# Altium schematic sheet TFT_LCD.SchDoc
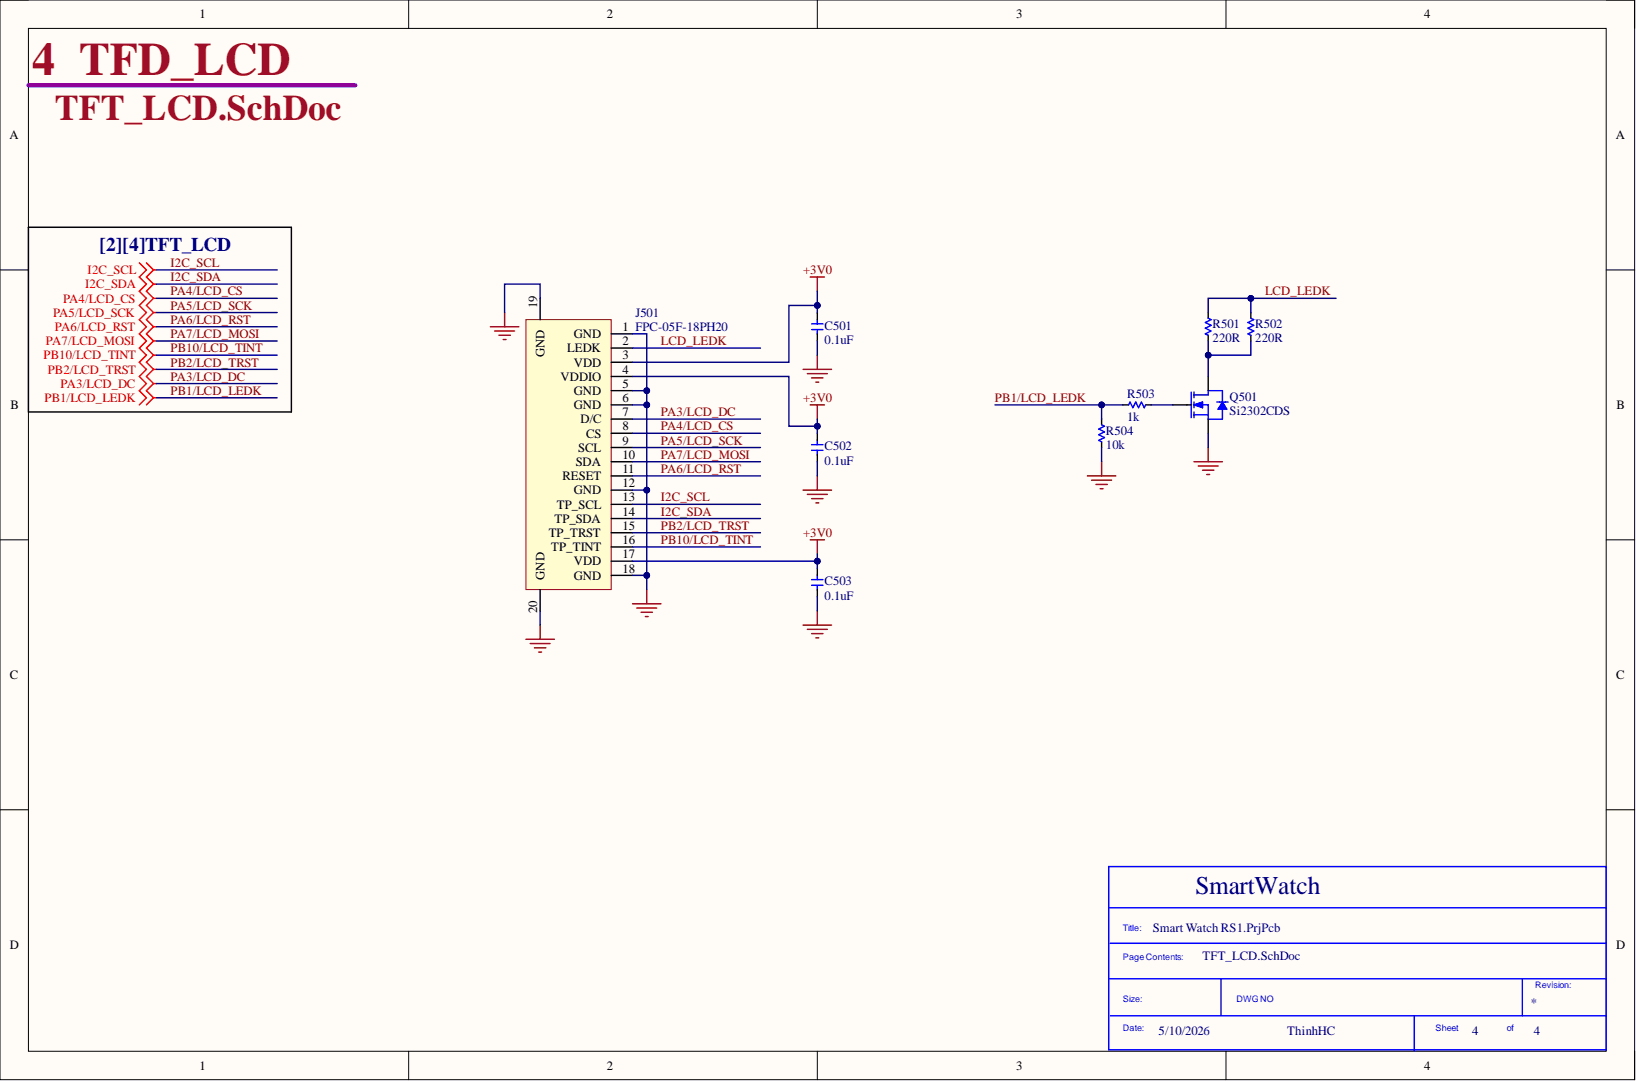
[diagram p0: TFT_LCD.SchDoc]

## Parts
C501  0.1uF  [C_0402]
C502  0.1uF  [C_0402]
C503  0.1uF  [C_0402]
J501  FPC-05F-18PH20  [FPC-SMD_18P-P0.50_FPC-05F-18PH20]
Q501  Si2302CDS  [SOT-23-3_L2.9-W1.3-P1.90-LS2.4-BR]
R501  220R  [R_0402]
R502  220R  [R_0402]
R503  1k  [R_0402]
R504  10k  [R_0402]

## Nets
+3V0: C501.2 C502.2 C503.2 J501.3(VDD) J501.4(VDDIO) J501.17(VDD)
GND: C501.1 C502.1 C503.1 J501.1(GND) J501.5(GND) J501.6(GND) J501.12(GND) J501.18(GND) J501.19(GND) J501.20(GND) Q501.2 R504.1
I2C_SCL: J501.13(TP_SCL)
I2C_SDA: J501.14(TP_SDA)
LCD_LEDK: J501.2(LEDK) R501.2 R502.2
PA3/LCD_DC: J501.7(D/C)
PA4/LCD_CS: J501.8(CS)
PA5/LCD_SCK: J501.9(SCL)
PA6/LCD_RST: J501.11(RESET)
PA7/LCD_MOSI: J501.10(SDA)
PB1/LCD_LEDK: R503.1 R504.2
PB2/LCD_TRST: J501.15(TP_TRST)
PB10/LCD_TINT: J501.16(TP_TINT)
NetQ501_1: Q501.1 R503.2
NetQ501_3: Q501.3 R501.1 R502.1

## Library data of the parts
C501-C503 = CL05B104KO5NNNC: 100nF 0402 16V X7R ±10% Multilayer Ceramic Capacitors MLCC - SMD/SMT ROHS; Manufacturer: Samsung Electro-Mechanics; Assembly Type: SMT Assembly; Component Kind: Standard; ComponentLink1Description: Digikey Link; ComponentLink1URL: https://www.digikey.com/en/products/detail/yageo/CC0603KRX7R9BB104/2103082?s=N4IgTCBcDaIMwEYEFoFwCzuWZA5AIiALoC%2BQA; ComponentLink2Description: LCSC Link; ComponentLink2URL: https://jlcpcb.com/parts/componentSearch?searchTxt=10k%200603; LCSC Part Number: C1525; Manufacturer Part Number: CL05B104KO5NNNC; Package: 0402; Library Name: HomeMade SmartWatch.SchLib
J501 = FPC-05F-18PH20: -25℃~+85℃ 0.3mm 0.5mm 18P 2mm 500mA 50V Bottom Contact Hinged Lid Phosphor bronze Surface Mount, Right Angle Tin SMD,P=0.5mm,Surface Mount，Right Angle FFC, FPC (Flat Flexible) Connector Assemblies ROHS; Manufacturer: XUNPU; Assembly Type: SMT Assembly; Component Kind: Standard; LCSC Part Number: C2856802; Manufacturer Part Number: FPC-05F-18PH20; Package: SMD,P=0.5mm,Surface Mount，Right Angle; Library Name: HomeMade SmartWatch.SchLib
Q501 = Si2302CDS: 1 N-channel 2.9A 20V 3.5nC@10V,4.5V 550mW 75mΩ@2.5V 850mV N-Channel SOT-23 MOSFETs ROHS; Manufacturer: Vishay Intertech; Manufacturer Part Number: Si2302CDS-T1-GE3; Assembly Type: SMT Assembly; Component Kind: Standard; ComponentLink1Description: Digikey Link; ComponentLink2Description: LCSC Link; ComponentLink2URL: https://jlcpcb.com/parts/componentSearch?searchTxt=10k%200603; LCSC Part Number: C10488; Library Name: Smart Watch RS1.SchLib; Package: SOT-23
R501 R502 = 0402WGF2200TCE: 220Ω 0402 50V -55℃~+155℃ 62.5mW Thick Film Resistor ±1% ±100ppm/℃ Chip Resistor - Surface Mount ROHS; Manufacturer: UNI-ROYAL(Uniroyal Elec); Assembly Type: SMT Assembly; Component Kind: Standard; LCSC Part Number: C25091; Library Name: Smart Watch RS1.SchLib; Manufacturer Part Number: 0402WGF2200TCE; Package: 0402
R503 = 0402WGF1001TCE: 1kΩ 0402 50V 62.5mW Thick Film Resistors ±1%  Chip Resistor - Surface Mount ROHS; Manufacturer: UNI-ROYAL(Uniroyal Elec); Assembly Type: SMT Assembly; Component Kind: Standard; LCSC Part Number: C11702; Library Name: Smart Watch RS1.SchLib; Manufacturer Part Number: 0402WGF1001TCE; Package: 0402
R504 = 0402WGF1002TCE: 10kΩ 0402 50V 62.5mW Thick Film Resistors ±1%  Chip Resistor - Surface Mount ROHS; Manufacturer: UNI-ROYAL(Uniroyal Elec); Assembly Type: SMT Assembly; Component Kind: Standard; LCSC Part Number: C25744; Library Name: Smart Watch RS1.SchLib; Manufacturer Part Number: 0402WGF1002TCE; Package: 0402
